# Altium schematic sheet gp4 CLA.SchDoc
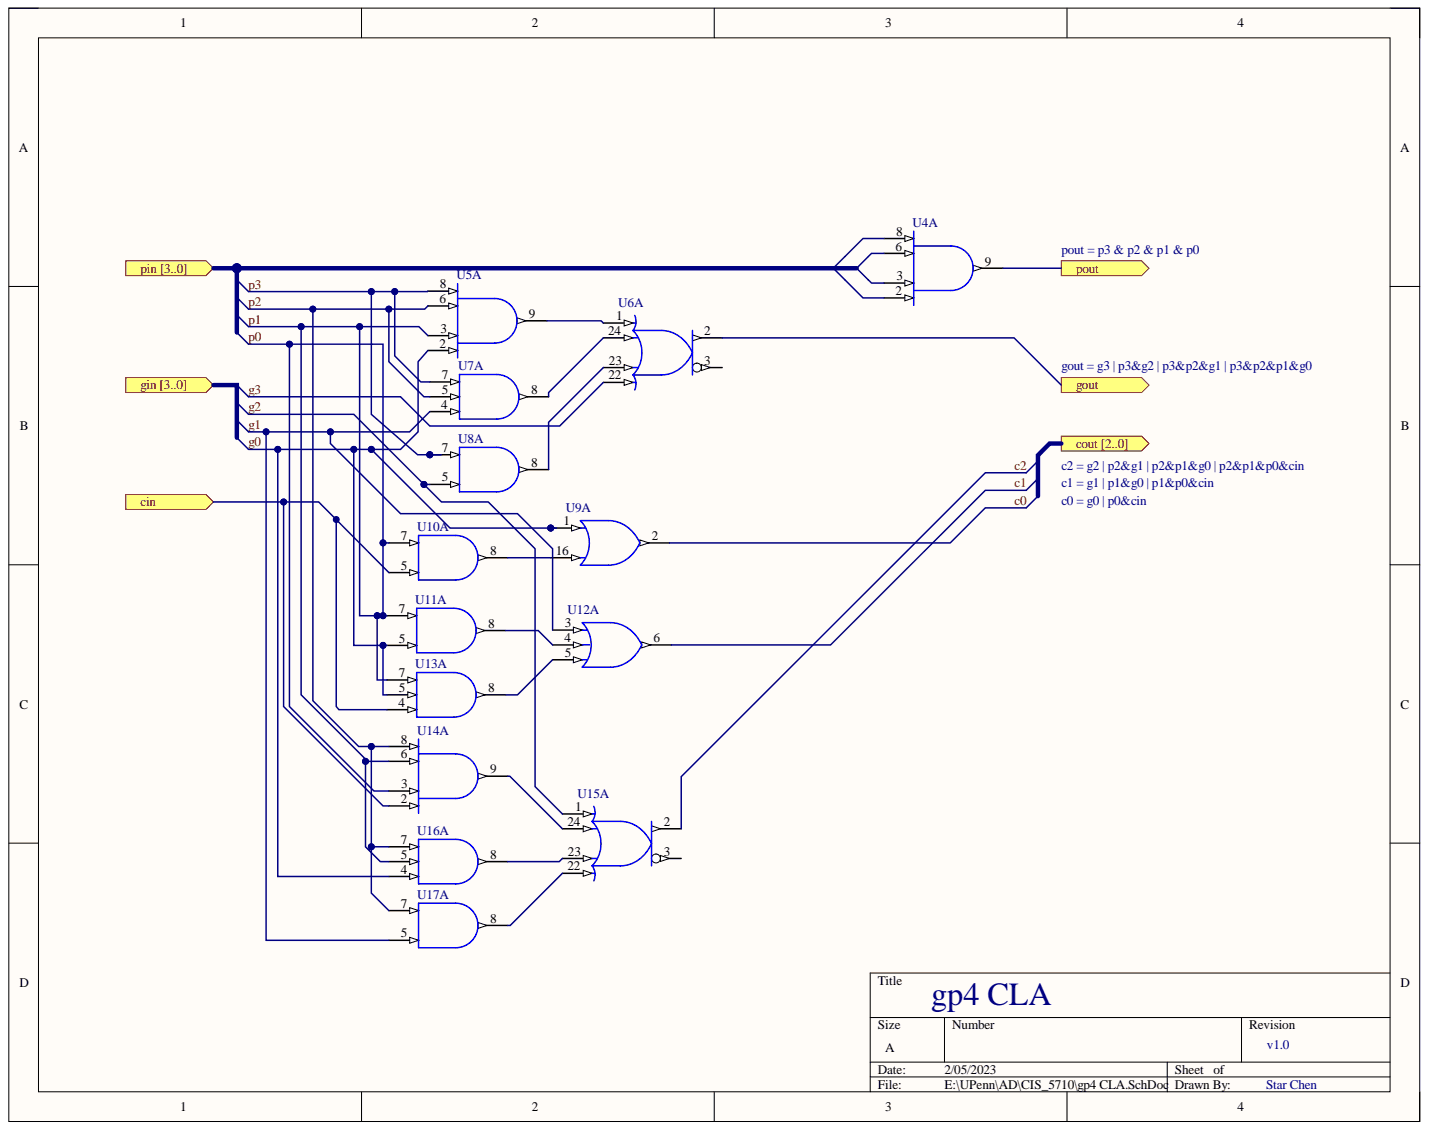
[diagram p0: gp4 CLA.SchDoc]

## Parts
U4  54AC11021FK  [FK020]  (value not drawn)  (part A)
U5  54AC11021FK  [FK020]  (value not drawn)  (part A)
U6  54ACT11802JT  [JT024C]  (value not drawn)  (part A)
U7  54AC11011FK  [FK020]  (value not drawn)  (part A)
U8  54AC11008FK  [FK020D]  (value not drawn)  (part A)
U9  54AC11032J  [J016D]  (value not drawn)  (part A)
U10  54AC11008FK  [FK020D]  (value not drawn)  (part A)
U11  54AC11008FK  [FK020D]  (value not drawn)  (part A)
U12  SN54HC4075J  [J014]  (value not drawn)  (part A)
U13  54AC11011FK  [FK020]  (value not drawn)  (part A)
U14  54AC11021FK  [FK020]  (value not drawn)  (part A)
U15  54ACT11802JT  [JT024C]  (value not drawn)  (part A)
U16  54AC11011FK  [FK020]  (value not drawn)  (part A)
U17  54AC11008FK  [FK020D]  (value not drawn)  (part A)

## Ports
cin, cout 0, cout 1, cout 2, cout [2..0], gin 0, gin 1, gin 2, gin 3, gin [3..0], gout, pin 0, pin 1, pin 2, pin 3, pin [3..0], pout

## Nets
GND: U4.10 U5.10 U6.5 U6.6 U6.7 U6.8 U7.10 U7.12 U8.10 U8.12 U9.4 U9.5 U10.10 U10.12 U11.10 U11.12 U12.7 U13.10 U13.12 U14.10 U15.5 U15.6 U15.7 U15.8 U16.10 U16.12 U17.10 U17.12
VCC: U4.20 U5.20 U6.18 U6.19 U7.2 U7.20 U8.2 U8.20 U9.12 U9.13 U10.2 U10.20 U11.2 U11.20 U12.14 U13.2 U13.20 U14.20 U15.18 U15.19 U16.2 U16.20 U17.2 U17.20
c0: U9.2
c1: U12.6
c2: U15.2
cin: U10.5 U13.4 U14.2 ; port cin
g0: U5.2 U9.1 U11.5 U13.5 U16.4
g1: U7.4 U12.3 U17.5
g2: U8.5 U15.1
g3: U6.22
gout: U6.2 ; port gout
p0: U5.3 U10.7 U11.7 U13.7 U14.3 U14.6 U16.5
p2: U5.6 U7.5 U14.8 U16.7 U17.7
p3: U5.8 U7.7 U8.7
pout: U4.9 ; port pout
NetU5_9: U5.9 U6.1
NetU6_23: U6.23 U8.8
NetU6_24: U6.24 U7.8
NetU9_16: U9.16 U10.8
NetU11_8: U11.8 U12.4
NetU12_5: U12.5 U13.8
NetU14_9: U14.9 U15.24
NetU15_22: U15.22 U17.8
NetU15_23: U15.23 U16.8
N00001_0: ; port gin [3..0]
N00001_1: ; port gin [3..0]
N00001_2: ; port gin [3..0]
N00001_3: ; port gin [3..0]
N00002_0: ; port cout [2..0]
N00002_1: ; port cout [2..0]
N00002_2: ; port cout [2..0]
N00003_0: ; port pin [3..0]
N00003_1: ; port pin [3..0]
N00003_2: ; port pin [3..0]
N00003_3: ; port pin [3..0]

Not connected: U4 2 3 6 8; U6 3; U15 3

## Library data of the parts
U4 U5 U14 = 54AC11021FK: Dual 4-Input Positive-AND Gate; Note: Pins 1, 4, 5, 7, 11, 15, 16 and 17 are not connected and not drawn; Component Kind: Standard; ComponentLink1Description: Manufacturer Link; ComponentLink1URL: http://www.ti.com/; ComponentLink2Description: Datasheet; ComponentLink2URL: http://www-s.ti.com/sc/ds/74ac11021.pdf; ComponentLink3Description: Packaging Specification; ComponentLink3URL: http://www-s.ti.com/sc/psheets/mlcc006b/mlcc006b.pdf; DatasheetVersion: Apr-1993; LatestRevisionDate: 17-Jul-2002; LatestRevisionNote: Re-released for DXP Platform.; Library Name: TI Logic Gate 1.IntLib; PackageDescription: Leadless Chip Carrier; 20 Terminals; Body 8.9 x 8.9 mm; PackageReference: FK020; PackageVersion: 1998; Published: 8-Jun-2000; Publisher: Altium Limited
U6 U15 = 54ACT11802JT: Triple 4-Input OR / NOR Clock Driver; Code_JEDEC: MS-001-AF; Component Kind: Standard; ComponentLink1Description: Manufacturer Link; ComponentLink1URL: http://www.ti.com/; ComponentLink2Description: Packaging Specification; ComponentLink2URL: http://focus.ti.com/lit/ml/mcer004a/mcer004a.pdf; DatasheetVersion: Jan-1992; LatestRevisionDate: 04-Mar-2005; LatestRevisionNote: Stylized 3D Model Added.; Library Name: TI Logic Gate 1.IntLib; PackageDescription: DIP; 24 Leads; Row Spacing 7.62 mm; Pitch 2.54 mm; PackageReference: JT024C; PackageVersion: Jan-1997; PCB3D: JT024; Published: 8-Jun-2000; Publisher: Altium Limited
U7 U13 U16 = 54AC11011FK: Triple 3-Input Positive-AND Gate; Note: Pins 1, 6, 11 and 16 are not connected and not drawn; Component Kind: Standard; ComponentLink1Description: Manufacturer Link; ComponentLink1URL: http://www.ti.com/; ComponentLink2Description: Datasheet; ComponentLink2URL: http://www-s.ti.com/sc/ds/74ac11011.pdf; ComponentLink3Description: Packaging Specification; ComponentLink3URL: http://www-s.ti.com/sc/psheets/mlcc006b/mlcc006b.pdf; DatasheetVersion: Apr-1993; LatestRevisionDate: 17-Jul-2002; LatestRevisionNote: Re-released for DXP Platform.; Library Name: TI Logic Gate 1.IntLib; PackageDescription: Leadless Chip Carrier; 20 Terminals; Body 8.9 x 8.9 mm; PackageReference: FK020; PackageVersion: 1998; Published: 8-Jun-2000; Publisher: Altium Limited
U8 U10 U11 U17 = 54AC11008FK: Quadruple 2-Input Positive-AND Gate; Note: Pins 1, 6, 11 and 16 are not connected and not drawn; Component Kind: Standard; ComponentLink1Description: Manufacturer Link; ComponentLink1URL: http://www.ti.com/; ComponentLink2Description: Packaging Specification; ComponentLink2URL: http://www-s.ti.com/sc/psheets/mlcc006b/mlcc006b.pdf; DatasheetVersion: Mar-1990; LatestRevisionDate: 17-Jul-2002; LatestRevisionNote: Re-released for DXP Platform.; Library Name: TI Logic Gate 1.IntLib; PackageDescription: Leadless Chip Carrier; 20 Terminals; Body 8.9 x 8.9 mm; PackageReference: FK020D; PackageVersion: 1998; Published: 8-Jun-2000; Publisher: Altium Limited
U9 = 54AC11032J: Quadruple 2-Input Positive-OR Gate; Component Kind: Standard; ComponentLink1Description: Manufacturer Link; ComponentLink1URL: http://www.ti.com/; ComponentLink2Description: Packaging Specification; ComponentLink2URL: http://focus.ti.com/lit/ml/mcer002d/mcer002d.pdf; DatasheetVersion: Mar-1990; LatestRevisionDate: 04-Mar-2005; LatestRevisionNote: Stylized 3D Model Added.; Library Name: TI Logic Gate 1.IntLib; PackageDescription: DIP; 16 Leads; Row Spacing 7.62 mm; Pitch 2.54 mm; PackageReference: J016D; PackageVersion: Jun-1999; PCB3D: J016; Published: 8-Jun-2000; Publisher: Altium Limited
U12 = SN54HC4075J: Triple 3-Input OR Gate; Component Kind: Standard; ComponentLink1Description: Manufacturer Link; ComponentLink1URL: http://www.ti.com/; ComponentLink2Description: Packaging Specification; ComponentLink2URL: http://focus.ti.com/lit/ml/mcer002d/mcer002d.pdf; DatasheetVersion: Sep-1987; LatestRevisionDate: 04-Mar-2005; LatestRevisionNote: Stylized 3D Model Added.; Library Name: TI Logic Gate 1.IntLib; PackageDescription: DIP; 14 Leads; Row Spacing 7.62 mm; Pitch 2.54 mm; PackageReference: J014; PackageVersion: Jun-1999; PCB3D: J014; Published: 8-Jun-2000; Publisher: Altium Limited
